# Altium schematic sheet Power_Regulation.SchDoc(Power_Regulation)
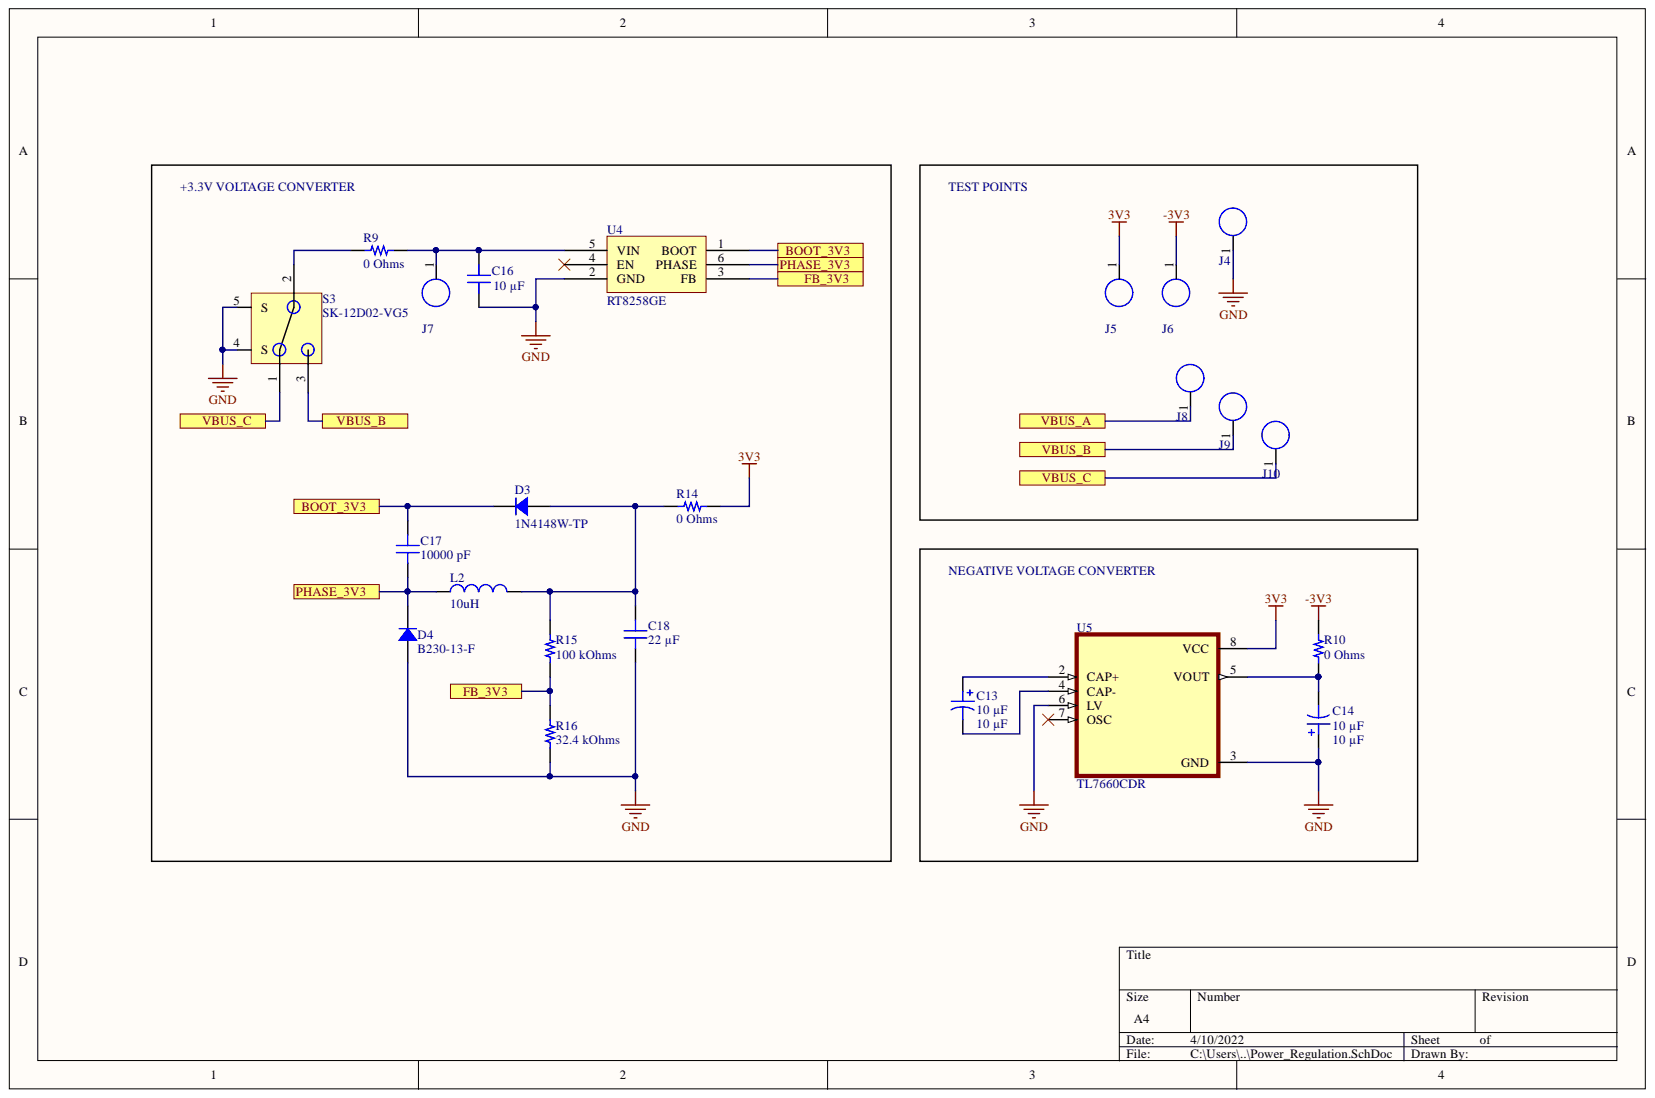
[diagram p0: Power_Regulation.SchDoc]

## Parts
C13  10 µF  [CAP POL 1411_3528]
C14  10 µF  [CAP POL 1411_3528]
C16  10 µF  [CAP 1206_3216 - 0.8MM]
C17  10000 pF  [CAP 0402_1005]
C18  22 µF  [CAP 1206_3216 - 0.8MM]
D3  1N4148W-TP  [SOD-123]
D4  B230-13-F  [DO214AA SMB]
J4  Large Board Solder Point  [Large Solder Point]  (value not drawn)  (no BOM)
J5  Large Board Solder Point  [Large Solder Point]  (value not drawn)  (no BOM)
J6  Large Board Solder Point  [Large Solder Point]  (value not drawn)  (no BOM)
J7  Large Board Solder Point  [Large Solder Point]  (value not drawn)  (no BOM)
J8  Large Board Solder Point  [Large Solder Point]  (value not drawn)  (no BOM)
J9  Large Board Solder Point  [Large Solder Point]  (value not drawn)  (no BOM)
J10  Large Board Solder Point  [Large Solder Point]  (value not drawn)  (no BOM)
L2  10uH  [0630CDMCCDS-100MC]
R9  0 Ohms  [RES 1210_3225]
R10  0 Ohms  [RES 1210_3225]
R14  0 Ohms  [RES 1210_3225]
R15  100 kOhms  [RES 0402_1005]
R16  32.4 kOhms  [RES 0402_1005]
S3  SK-12D02-VG5  [SK-12D02-VG5]
U4  RT8258GE  [SOT100P279X130-6N]
U5  TL7660CDR  [SOIC127P599X175-8N]

## Ports
BOOT_3V3, FB_3V3, PHASE_3V3, VBUS_A, VBUS_B, VBUS_C

## Nets
3V3: J5.1 R14.2 U5.8(VCC)
-3V3: J6.1 R10.2
GND: C14.1 C16.1 C18.2 D4.1 J4.1 R16.1 S3.4(S) S3.5(S) U4.2(GND) U5.3(GND) U5.6(LV)
BOOT_3V3: C17.2 D3.2 U4.1(BOOT) ; port BOOT_3V3
FB_3V3: R15.1 R16.2 U4.3(FB) ; port FB_3V3
PHASE_3V3: C17.1 D4.2 L2.1 U4.6(PHASE) ; port PHASE_3V3
VBUS_A: J8.1 ; port VBUS_A
VBUS_B: J9.1 S3.3 ; port VBUS_B
VBUS_C: J10.1 S3.1 ; port VBUS_C
NetC13_1: C13.1 U5.2(CAP+)
NetC13_2: C13.2 U5.4(CAP-)
NetC14_2: C14.2 R10.1 U5.5(VOUT)
NetC16_2: C16.2 J7.1 R9.2 U4.5(VIN)
NetC18_1: C18.1 D3.1 L2.2 R14.1 R15.2
NetR9_1: R9.1 S3.2

Not connected: U4 4(EN); U5 7(OSC)

## Library data of the parts
C13 C14 = T491T106K016AT: CAP TANT 10UF 10% 16V 1411; Manufacturer Size Code: T; manufacturer: KEMET; Type: Molded; Lifetime @ Temp.: 2000 Hrs @ 125°C; ESR (Equivalent Series Resistance): 8Ohm; Database Table Name: Capacitors - Tantalum; Voltage - Rated: 16 V; Value: 10 µF; Tolerance: ±10%; Supplier Part Number 1: 399-8400-1-ND; Supplier 1: DigiKey; Size / Dimension: 0.138" L x 0.110" W (3.50mm x 2.80mm); series: T491; price: 0.46; Part Status: Active; Part Number: T491T106K016AT; partid: 5; packaging: TapeAndReel; Package / Case: 1411 (3528 Metric); Operating Temperature: -55°C ~ 125°C; Mounting Type: Surface Mount; Minimum Order: 1; Lifecycle Status: Active; Library Name: Celestial Altium Library - altium_library.DbLib; lastupdated: 27/08/2022 8:06:59 AM; Height - Seated (Max): 0.047" (1.20mm); Features: General Purpose; Device Package: 1411 (3528 Metric); componentlink2url: https://www.digikey.com/en/products/detail/kemet/T491T106K016AT/2336362; componentlink2description: DigiKey Link; componentlink1url: https://api.kemet.com/component-edge/download/datasheet/T491T106K016AT.pdf; componentlink1description: Datasheet; Component Kind: Standard; Capacitance: 10 µF
C16 = GRM31CR71E106MA12K: CAP CER 10UF 25V X7R 1206; manufacturer: Murata Electronics; Tolerance: ±20%; Database Table Name: Capacitors - Ceramic - 1206; Voltage - Rated: 25V; Value: 10 µF; Thickness (Max): 0.071" (1.80mm); Temperature Coefficient: X7R; Supplier Part Number 1: 490-GRM31CR71E106MA12KCT-ND; Supplier 1: DigiKey; Size / Dimension: 0.126" L x 0.063" W (3.20mm x 1.60mm); series: GRM; price: 0.07; Part Status: Active; Part Number: GRM31CR71E106MA12K; partid: 30277; packaging: TapeAndReel; Package / Case: 1206 (3216 Metric); Operating Temperature: -55°C ~ 125°C; Mounting Type: Surface Mount, MLCC; Minimum Order: 1; Lifecycle Status: Active; Library Name: Celestial Altium Library - altium_library.DbLib; lastupdated: 9/08/2022 12:05:16 PM; Device Package: 1206 (3216 Metric); componentlink2url: https://www.digikey.com/en/products/detail/murata-electronics/GRM31CR71E106MA12K/13904832; componentlink2description: DigiKey Link; componentlink1url: https://search.murata.co.jp/Ceramy/image/img/A01X/G101/ENG/GRM31CR71E106MA12-01CA.pdf; componentlink1description: Datasheet; Component Kind: Standard; Capacitance: 10 µF; Applications: General Purpose
C17 = VJ0402Y103JXQCW1BC: CAP CER 10000PF 10V X7R 0402; manufacturer: Vishay Vitramon; Tolerance: ±5%; Database Table Name: Capacitors - Ceramic - 0402; Voltage - Rated: 10V; Value: 10000 pF; Thickness (Max): 0.022" (0.55mm); Temperature Coefficient: X7R; Supplier Part Number 1: 720-1645-1-ND; Supplier 1: DigiKey; Size / Dimension: 0.039" L x 0.020" W (1.00mm x 0.50mm); series: VJ; price: 0.01; Part Status: Active; Part Number: VJ0402Y103JXQCW1BC; partid: 4459; packaging: TapeAndReel; Package / Case: 0402 (1005 Metric); Operating Temperature: -55°C ~ 125°C; Mounting Type: Surface Mount, MLCC; Minimum Order: 1; Lifecycle Status: Active; Library Name: Celestial Altium Library - altium_library.DbLib; lastupdated: 9/08/2022 12:01:13 PM; Device Package: 0402 (1005 Metric); componentlink2url: https://www.digikey.com/en/products/detail/vishay-vitramon/VJ0402Y103JXQCW1BC/8301965; componentlink2description: DigiKey Link; componentlink1url: https://www.vishay.com/docs/28548/vjw1bcbascomseries.pdf; componentlink1description: Datasheet; Component Kind: Standard; Capacitance: 10000 pF; Applications: General Purpose
C18 = GCM31CR70J226KE23L: CAP CER 22UF 6.3V X7R 1206; manufacturer: Murata Electronics; Tolerance: ±10%; Database Table Name: Capacitors - Ceramic - 1206; Voltage - Rated: 6.3V; Value: 22 µF; Thickness (Max): 0.071" (1.80mm); Temperature Coefficient: X7R; Supplier Part Number 1: 490-4843-1-ND; Supplier 1: DigiKey; Size / Dimension: 0.126" L x 0.063" W (3.20mm x 1.60mm); series: GCM; Ratings: AEC-Q200; price: 0.19; Part Status: Active; Part Number: GCM31CR70J226KE23L; partid: 19505; packaging: TapeAndReel; Package / Case: 1206 (3216 Metric); Operating Temperature: -55°C ~ 125°C; Mounting Type: Surface Mount, MLCC; Minimum Order: 1; Lifecycle Status: Active; Library Name: Celestial Altium Library - altium_library.DbLib; lastupdated: 9/08/2022 12:05:38 PM; Device Package: 1206 (3216 Metric); componentlink2url: https://www.digikey.com/en/products/detail/murata-electronics/GCM31CR70J226KE23L/1763914; componentlink2description: DigiKey Link; componentlink1url: https://www.murata.com/~/media/webrenewal/support/library/catalog/products/capacitor/mlcc/c03e.ashx?la=en-us; componentlink1description: Datasheet; Component Kind: Standard; Capacitance: 22 µF; Applications: Automotive
D3 = 1N4148W-TP: DIODE GEN PURP 100V 150MA SOD123; Manufacturer: Micro Commercial Co; Voltage - Forward (Vf) (Max) @ If: 1.25 V @ 150 mA; Speed: Small Signal =< 200mA (Io), Any Speed; Reverse Recovery Time (trr): 4 ns; Diode Type: Standard; Database Table Name: Diodes - Rectifiers - Single; Current - Reverse Leakage @ Vr: 1 µA @ 75 V; Capacitance @ Vr, F: 2pF @ 0V, 1MHz; Voltage - DC Reverse (Vr) (Max): 100 V; Supplier Part Number 1: 1N4148WTPMSCT-ND; Supplier Device Package: SOD-123; Supplier 1: DigiKey; Series: -; Price: 0.02; Part Status: Active; Part Number: 1N4148W-TP; PartId: 999; Packaging: TapeAndReel; Package / Case: SOD-123; Operating Temperature - Junction: -65°C ~ 150°C; Mounting Type: Surface Mount; Minimum Order: 1; Lifecycle Status: Active; Library Name: Celestial Altium Library - altium_library.DbLib; LastUpdated: 9/08/2022 12:37:52 PM; Current - Average Rectified (Io): 150mA; ComponentLink2URL: https://www.digikey.com/en/products/detail/micro-commercial-co/1N4148W-TP/717196; ComponentLink2Description: DigiKey Link; ComponentLink1URL: https://www.mccsemi.com/pdf/Products/1N4148W(SOD123).pdf; ComponentLink1Description: Datasheet; Component Kind: Standard
D4 = B230-13-F: DIODE SCHOTTKY 30V 2A SMB; Manufacturer: Diodes Incorporated; Voltage - Forward (Vf) (Max) @ If: 500 mV @ 2 A; Speed: Fast Recovery =< 500ns, > 200mA (Io); Database Table Name: Diodes - Rectifiers - Single; Current - Reverse Leakage @ Vr: 500 µA @ 30 V; Capacitance @ Vr, F: 200pF @ 4V, 1MHz; Voltage - DC Reverse (Vr) (Max): 30 V; Supplier Part Number 1: B230-FDICT-ND; Supplier Device Package: SMB; Supplier 1: DigiKey; Series: -; Price: 0.13; Part Status: Active; Part Number: B230-13-F; PartId: 1539; Packaging: TapeAndReel; Package / Case: DO-214AA, SMB; Operating Temperature - Junction: -65°C ~ 150°C; Mounting Type: Surface Mount; Minimum Order: 1; Lifecycle Status: Active; Library Name: Celestial Altium Library - altium_library.DbLib; LastUpdated: 9/08/2022 12:37:20 PM; Diode Type: Schottky; Current - Average Rectified (Io): 2A; ComponentLink2URL: https://www.digikey.com/en/products/detail/diodes-incorporated/B230-13-F/814955; ComponentLink2Description: DigiKey Link; ComponentLink1URL: https://www.diodes.com/assets/Datasheets/ds13004.pdf; ComponentLink1Description: Datasheet; Component Kind: Standard
L2 = 0630CDMCCDS-100MC: 4A 10uH ±20% 67mΩ SMD,7x6.6x2.8mm Power Inductors ROHS; Library Name: Schlib2.SchLib; Component Kind: Standard
R9 R10 R14 = RC1210JR-070RL: RES 0 OHM JUMPER 1/2W 1210; Manufacturer: Yageo; Power (Watts): 0.5W, 1/2W; Database Table Name: Resistors - Surface Mount - 1210; Value: 0 Ohms; Tolerance: Jumper; Supplier Part Number 1: YAG3375CT-ND; Supplier Device Package: 1210; Supplier 1: DigiKey; Size / Dimension: 0.122" L x 0.102" W (3.10mm x 2.60mm); Series: RC_L; Resistance: 0 Ohms; Price: 0.01; Part Status: Active; Part Number: RC1210JR-070RL; Packaging: TapeAndReel; Package / Case: 1210 (3225 Metric); Operating Temperature: -55°C ~ 155°C; Number of Terminations: 2; Minimum Order: 1; Lifecycle Status: Active; Library Name: Celestial Altium Library - altium_library.DbLib; LastUpdated: 9/08/2022 12:50:16 PM; Height - Seated (Max): 0.024" (0.60mm); Features: Moisture Resistant; Device Package: 1210 (3225 Metric); Composition: Thick Film; ComponentLink2URL: https://www.digikey.com/en/products/detail/yageo/RC1210JR-070RL/5919839; ComponentLink2Description: DigiKey Link; ComponentLink1URL: https://www.yageo.com/upload/media/product/productsearch/datasheet/rchip/PYu-RC_Group_51_RoHS_L_10.pdf; ComponentLink1Description: Datasheet; Component Kind: Standard
R15 = RP73PF1E100KBTD: RES 100K OHM 0.1% 1/10W 0402; Manufacturer: TE Connectivity Passive Product; Temperature Coefficient: ±25ppm/°C; Power (Watts): 0.1W, 1/10W; Database Table Name: Resistors - Surface Mount - 0402; Value: 100 kOhms; Tolerance: ±0.1%; Supplier Part Number 1: A127511CT-ND; Supplier Device Package: 0402; Supplier 1: DigiKey; Size / Dimension: 0.039" L x 0.020" W (1.00mm x 0.50mm); Series: RP73, Holsworthy; Resistance: 100 kOhms; Price: 0.09; Part Status: Active; Part Number: RP73PF1E100KBTD; PartId: 2436; Packaging: TapeAndReel; Package / Case: 0402 (1005 Metric); Operating Temperature: -55°C ~ 155°C; Number of Terminations: 2; Minimum Order: 1; Lifecycle Status: Active; Library Name: Celestial Altium Library - altium_library.DbLib; LastUpdated: 9/08/2022 12:11:04 PM; Height - Seated (Max): 0.014" (0.35mm); Device Package: 0402 (1005 Metric); Composition: Thin Film; ComponentLink2URL: https://www.digikey.com/en/products/detail/te-connectivity-passive-product/RP73PF1E100KBTD/8261053; ComponentLink2Description: DigiKey Link; ComponentLink1URL: https://www.te.com/commerce/DocumentDelivery/DDEController?Action=srchrtrv&DocNm=1773272&DocType=DS&DocLang=English; ComponentLink1Description: Datasheet; Component Kind: Standard
R16 = CPF0402B32K4E1: RES SMD 32.4KOHM 0.1% 1/16W 0402; Manufacturer: TE Connectivity Passive Product; Temperature Coefficient: ±25ppm/°C; Power (Watts): 0.063W, 1/16W; Database Table Name: Resistors - Surface Mount - 0402; Value: 32.4 kOhms; Tolerance: ±0.1%; Supplier Part Number 1: A102795CT-ND; Supplier Device Package: 0402; Supplier 1: DigiKey; Size / Dimension: 0.039" L x 0.020" W (1.00mm x 0.50mm); Series: CPF, Neohm; Resistance: 32.4 kOhms; Price: 0.15; Part Status: Active; Part Number: CPF0402B32K4E1; PartId: 4116; Packaging: TapeAndReel; Package / Case: 0402 (1005 Metric); Operating Temperature: -55°C ~ 155°C; Number of Terminations: 2; Minimum Order: 1; Lifecycle Status: Active; Library Name: Celestial Altium Library - altium_library.DbLib; LastUpdated: 9/08/2022 12:10:59 PM; Height - Seated (Max): 0.014" (0.35mm); Device Package: 0402 (1005 Metric); Composition: Thin Film; ComponentLink2URL: https://www.digikey.com/en/products/detail/te-connectivity-passive-product/CPF0402B32K4E1/2382531; ComponentLink2Description: DigiKey Link; ComponentLink1URL: https://www.te.com/commerce/DocumentDelivery/DDEController?Action=srchrtrv&DocNm=1773200&DocType=DS&DocLang=English; ComponentLink1Description: Datasheet; Component Kind: Standard
S3 = SK-12D02-VG5: Lying SPDT 9.5mm PCPin 5000 Rectangular columnar -25℃~+70℃ Plugin Slide Switches ROHS SP#C136722; Component Kind: Standard; Library Name: Power_Measurement.SchLib
U4 = RT8258GE: RICHTEK 1.2A, 24V, 700kHz Step-Down Converter SOT23-6; Library Name: Schlib2.SchLib; Component Kind: Standard
U5 = TL7660CDR: MF: {'manufacturer': u'Texas Instruments'}; Price: None; Package: None; MP: TL7660CDR; Library Name: TL7660CDR.SchLib; Component Kind: Standard; Availability: Unavailable
J4-J10 = Large Board Solder Point: Library Name: Schlib2.SchLib; Description: Large Board Solder Point
